# Altium schematic sheet 09_PERIPHERALS.SchDoc(09_PERIPHERALS)
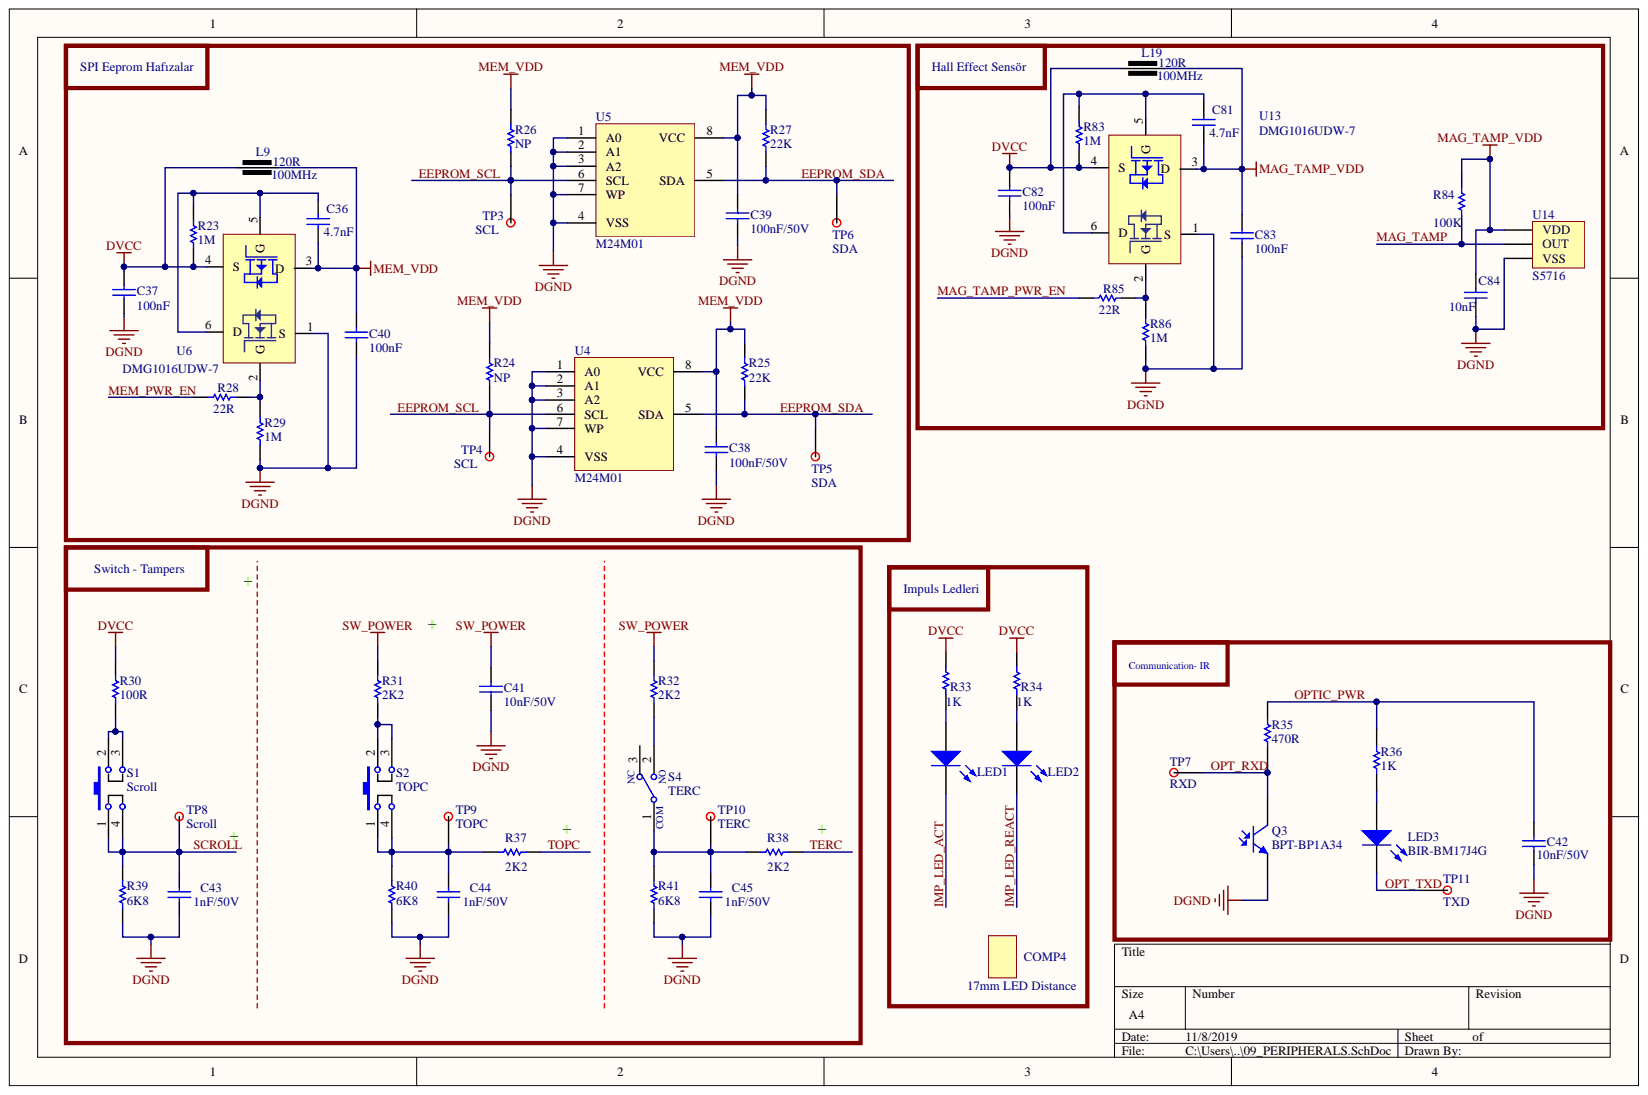
[diagram p0: 09_PERIPHERALS.SchDoc]

## Parts
C36  Capacitance: 4.7nF  [CAP_0603_V1]  (hidden comment: CAP_SMD)
C37  Capacitance: 100nF  [CAP_0603_V1]  (hidden comment: CAP_SMD)
C38  100nF  [FERRITE-BEAD_0603_V1]
C39  100nF  [FERRITE-BEAD_0603_V1]
C40  Capacitance: 100nF  [CAP_0603_V1]  (hidden comment: CAP_SMD)
C41  value 10nF/50V  [CAP_0603_V1]  (hidden comment: CAP_SMD)
C42  10nF/50V  [CAP_0603_V1]
C43  value 1nF/50V  [CAP_0603_V1]  (hidden comment: CAP_SMD)
C44  value 1nF/50V  [CAP_0603_V1]  (hidden comment: CAP_SMD)
C45  value 1nF/50V  [CAP_0603_V1]  (hidden comment: CAP_SMD)
C81  Capacitance: 4.7nF  [CAP_0603_V1]  (hidden comment: CAP_SMD)
C82  Capacitance: 100nF  [CAP_0603_V1]  (hidden comment: CAP_SMD)
C83  Capacitance: 100nF  [CAP_0603_V1]  (hidden comment: CAP_SMD)
C84  Capacitance: 10nF  [CAP_0603_V1]  (hidden comment: CAP_SMD)
COMP4  value 17mm LED Distance  [00_BOS]  (hidden comment: PCB)
L9  Test Frequency: 100MHz  Impedance: 120R  [FERRITE-BEAD_0603_V1]  (hidden comment: FERRITE BEAD)
L19  Test Frequency: 100MHz  Impedance: 120R  [FERRITE-BEAD_0603_V1]  (hidden comment: FERRITE BEAD)
LED1  LED  [LED_THT_3MM_ROUND_004]
LED2  LED  [LED_THT_3MM_ROUND_004]
LED3  017_Manufacturer-1 PN: BIR-BM17J4G  [LED_THT_5MM_IR_ROUND_002]  (hidden comment: LED_INFRARED)
Q3  017_Manufacturer-1 PN: BPT-BP1A34  [PHOTOTRANSISTOR_THT_5MM_ROUND - V2]  (hidden comment: PHOTOTRANSISTOR)
R23  004_Value: 1M  [RES_0603_V1]  (hidden comment: RES_SMD)
R24  004_Value: NP  [RES_0603_V1]  (hidden comment: 4K7/50V)
R25  22K  [RES_0603_V1]
R26  004_Value: NP  [RES_0603_V1]  (hidden comment: 4K7/50V)
R27  22K  [RES_0603_V1]
R28  004_Value: 22R  [RES_0603_V1]  (hidden comment: RES_SMD)
R29  004_Value: 1M  [RES_0603_V1]  (hidden comment: RES_SMD)
R30  value 100R  [RES_0603_V1]  (hidden comment: RES_SMD)
R31  value 2K2  [RES_0603_V1]  (hidden comment: RES_SMD)
R32  value 2K2  [RES_0603_V1]  (hidden comment: RES_SMD)
R33  Resistance: 1K  [RES_0603_V1]  (hidden comment: RES_SMD)
R34  Resistance: 1K  [RES_0603_V1]  (hidden comment: RES_SMD)
R35  470R  [RES_0603_V1]
R36  1K  [RES_0603_V1]
R37  value 2K2  [RES_0603_V1]  (hidden comment: RES_SMD)
R38  value 2K2  [RES_0603_V1]  (hidden comment: RES_SMD)
R39  value 6K8  [RES_0603_V1]  (hidden comment: RES_SMD)
R40  value 6K8  [RES_0603_V1]  (hidden comment: RES_SMD)
R41  value 6K8  [RES_0603_V1]  (hidden comment: RES_SMD)
R83  004_Value: 1M  [RES_0603_V1]  (hidden comment: RES_SMD)
R84  004_Value: 100K  [RES_0603_V1]  (hidden comment: RES_SMD)
R85  004_Value: 22R  [RES_0603_V1]  (hidden comment: RES_SMD)
R86  004_Value: 1M  [RES_0603_V1]  (hidden comment: RES_SMD)
S1  value Scroll  [SWITCH_THT_PUSH_BUTTON - V2]  (hidden comment: SWITCH-PUSH_BUTTON)
S2  value TOPC  [SWITCH_THT_PUSH_BUTTON - V2]  (hidden comment: SWITCH-PUSH_BUTTON)
S4  value TERC  [HEADER_1X2_V4]  (hidden comment: SWITCH-SPDT)
TP3  value SCL  [TEST-PAD_2.0X2.0_ROUND - No_3D_Step]  (hidden comment: TEST_POINT)
TP4  value SCL  [TEST-PAD_2.0X2.0_ROUND - No_3D_Step]  (hidden comment: TEST_POINT)
TP5  value SDA  [TEST-PAD_2.0X2.0_ROUND - No_3D_Step]  (hidden comment: TEST_POINT)
TP6  value SDA  [TEST-PAD_2.0X2.0_ROUND - No_3D_Step]  (hidden comment: TEST_POINT)
TP7  004_Value: RXD  [TEST-PAD_2.0X2.0_ROUND - No_3D_Step]  (hidden comment: TEST_POINT)
TP8  value Scroll  [TEST-PAD_2.0X2.0_ROUND - No_3D_Step]  (hidden comment: TEST_POINT)
TP9  value TOPC  [TEST-PAD_2.0X2.0_ROUND - No_3D_Step]  (hidden comment: TEST_POINT)
TP10  value TERC  [TEST-PAD_2.0X2.0_ROUND - No_3D_Step]  (hidden comment: TEST_POINT)
TP11  004_Value: TXD  [TEST-PAD_2.0X2.0_ROUND - No_3D_Step]  (hidden comment: TEST_POINT)
U4  M24M01  [SOIC-8]
U5  M24M01  [SOIC-8]
U6  DMG1016UDW-7  [SOT363(TSSOP6)_TSOP65P210X110-6N]
U13  DMG1016UDW-7  [SOT363(TSSOP6)_TSOP65P210X110-6N]
U14  S5716  [SOT23(TO-236)]

## Nets
DGND: C37.1 C38.1 C39.1 C40.1 C41.2 C42.2 C43.1 C44.1 C45.1 C82.1 C83.1 C84.1 Q3.1 R29.1 R39.2 R40.2 R41.2 R86.1 U4.1(A0) U4.2(A1) U4.3(A2) U4.4(VSS) U4.7(WP) U5.1(A0) U5.2(A1) U5.3(A2) U5.4(VSS) U5.7(WP) U6.1(S) U13.1(S) U14.3
DVCC: C37.2 C82.2 L9.1 L19.1 R23.1 R30.1 R33.2 R34.2 R83.1 U6.4(S) U13.4(S)
MAG_TAMP_VDD: C81.1 C83.2 C84.2 L19.2 R84.2 U13.3(D) U14.1
MEM_VDD: C36.1 C38.2 C39.2 C40.2 L9.2 R24.2 R25.2 R26.2 R27.2 U4.8(VCC) U5.8(VCC) U6.3(D)
SW_POWER: C41.1 R31.1 R32.1
EEPROM_SCL: R24.1 R26.1 TP3.1 TP4.1 U4.6(SCL) U5.6(SCL)
EEPROM_SDA: R25.1 R27.1 TP5.1 TP6.1 U4.5(SDA) U5.5(SDA)
IMP_LED_ACT: LED1.2
IMP_LED_REACT: LED2.2
MAG_TAMP: R84.1 U14.2
MAG_TAMP_PWR_EN: R85.1
MEM_PWR_EN: R28.1
OPT_RXD: Q3.2 R35.1 TP7.1
OPT_TXD: LED3.2 TP11.1
OPTIC_PWR: C42.1 R35.2 R36.2
SCROLL: C43.2 R39.1 S1.1 S1.4 TP8.1
TERC: R38.2
TOPC: R37.2
NetC36_2: C36.2 R23.2 U6.5(G) U6.6(D)
NetC44_2: C44.2 R37.1 R40.1 S2.1 S2.4 TP9.1
NetC45_2: C45.2 R38.1 R41.1 S4.1 TP10.1
NetC81_2: C81.2 R83.2 U13.5(G) U13.6(D)
NetLED1_1: LED1.1 R33.1
NetLED2_1: LED2.1 R34.1
NetLED3_1: LED3.1 R36.1
NetR28_2: R28.2 R29.2 U6.2(G)
NetR30_2: R30.2 S1.2 S1.3
NetR31_2: R31.2 S2.2 S2.3
NetR32_2: R32.2 S4.2
NetR85_2: R85.2 R86.2 U13.2(G)

Not connected: S4 3

## Library data of the parts
C36 C81 = CAP_SMD: 017_Manufacturer-1 PN: CL10B472KB8SFNC; 016_Manufacturer-1: Samsung; Voltage Rating: 50V; Tolerance: 10%; Parameter Name: Tape and Reel; Dielectric: X7R; 006_SMT/THT: Surface Mount; 001_Description: CAP CER 4700PF 50V X7R 0603; Width: 0.031inch; Thickness: 0.035inch; Supplier Part Number 1: CL10B472KB8SFNC-REEL; Supplier 1: Future Electronics; Stock 1: 0; Radiation Hardening: No; Package Quantity: 1; Min Operating Temperature: -55°C; Max Operating Temperature: 125°C; Library Name: ElectricMeter.SchLib; Length: 0.063inch; Height: 0.8mm; Features: Soft Termination; Depth: 0.8mm; ComponentLink1URL: http://www.samsungsem.com/kr/support/product-search/mlcc/CL10B472KB8SFNC.jsp?src-supplier=Digi-Key; ComponentLink1Description: http://www.samsungsem.com/kr/support/product-search/mlcc/CL10B472KB8SFNC.jsp?src-supplier=Digi-Key; Component Kind: Standard; Case Code (Metric): 1608; Case Code (Imperial): 0603; Case/Package: 0603
C37 C40 C82 C83 = CAP_SMD: MLCC; 100nF; 50V; X7R; ±10%; SMD; 0603; -55÷125°C; 017_Manufacturer-1 PN: CL10B104KB8NNNC; 016_Manufacturer-1: Samsung; Parameter Name: Tape and Reel; Material: Ceramic; 006_SMT/THT: Surface Mount; 001_Description: Capacitor: ceramic; MLCC; 100nF; 50V; X7R; ±10%; SMD; 0603; -55÷125°C; Width: 0.031inch; Voltage Rating (DC): 50V; Voltage Rating: 50V; Voltage: 50V; Tolerance: 10%; Thickness: 0.035inch; Supplier Part Number 1: 766-5443; Supplier 1: RSComponents; Stock 1: 0; Radiation Hardening: No; Package Quantity: 1; Min Operating Temperature: -55°C; Max Operating Temperature: 125°C; Library Name: ElectricMeter.SchLib; Length: 1.6mm; Lead Free: Lead Free; Height: 0.8mm; Dielectric: X7R; Depth: 0.8mm; Contact Plating: Tin; ComponentLink3URL: https://produktinfo.conrad.com/datenblaetter/450000-474999/456651-da-01-en-VS_KONDENSATOR_0605.pdf?src-supplier=Conrad; ComponentLink3Description: https://produktinfo.conrad.com/datenblaetter/450000-474999/456651-da-01-en-VS_KONDENSATOR_0605.pdf?src-supplier=Conrad; ComponentLink2URL: https://www.verical.com/server-webapp/html/getDatasheet?partID=89097&src-supplier=Verical; ComponentLink2Description: https://www.verical.com/server-webapp/html/getDatasheet?partID=89097&src-supplier=Verical; ComponentLink1URL: http://www.samsungsem.com/kr/support/product-search/mlcc/CL10B104KB8NNNC.jsp?src-supplier=Digi-Key; ComponentLink1Description: http://www.samsungsem.com/kr/support/product-search/mlcc/CL10B104KB8NNNC.jsp?src-supplier=Digi-Key; Component Kind: Standard; Case Code (Metric): 1608; Case Code (Imperial): 0603; Case/Package: 0603
C38 C39 = 100nF/50V: MLCC, 100nF, 50V, X7R, 0603, %10; 017_Manufacturer-1 PN: CL10B104KB8NNNC; 016_Manufacturer-1: Samsung; 008_Package: C0603; 007_Status: MP; 006_SMT/THT: SMT; 005_Process: SMD_Mounter; 001_Designation: Capacitor; Library Name: BM.10.LC02.SCHLIB; Component Kind: Standard; 004_Value: 100nF/50V
C41 = CAP_SMD: MLCC, 10nF, 50V, X7R, 0603, %10; Component Kind: Standard; Library Name: HK_SCH_BAYLAN.SchLib
C42 = CAP_SMD: MLCC, 10nF, 50V, X7R, 0603, %10; 017_Manufacturer-1 PN: GRM188R71H103KA01D; 016_Manufacturer-1: Murata; Tolerance: 10%; Parameter Name: Tape and Reel; Material: Ceramic; Insulation Resistance: 10GR; Width: 0.031inch; Voltage Rating (DC): 50V; Voltage Rating: 50V; Voltage: 50V; Thickness: 0.035inch; Termination: SMD/SMT; Supplier Part Number 1: 204-0779P; Supplier 1: RSComponents; Stock 1: 0; REACH SVHC: Unknown; Package Quantity: 1; Number of Pins: 2; Mount: Surface Mount; Min Operating Temperature: -55°C; Max Operating Temperature: 125°C; Library Name: SCH_LIB.SCHLIB; Length: 1.6mm; Lead Free: Lead Free; Height: 0.8mm; Dielectric Material: Ceramic; Dielectric: X7R; Depth: 0.8mm; ComponentLink6URL: https://produktinfo.conrad.com/datenblaetter/450000-474999/451715-da-01-en-VS_KONDENSATOR_0603.pdf?src-supplier=Conrad; ComponentLink6Description: https://produktinfo.conrad.com/datenblaetter/450000-474999/451715-da-01-en-VS_KONDENSATOR_0603.pdf?src-supplier=Conrad; ComponentLink5URL: https://www.distrelec.biz/Web/Downloads/_t/ds/murata_MLCC_eng_tds.pdf?mime=application%2Fpdf&src-supplier=Distrelec; ComponentLink5Description: https://www.distrelec.biz/Web/Downloads/_t/ds/murata_MLCC_eng_tds.pdf?mime=application%2Fpdf&src-supplier=Distrelec; ComponentLink4URL: http://www.farnell.com/datasheets/2047844.pdf?src-supplier=Element14; ComponentLink4Description: http://www.farnell.com/datasheets/2047844.pdf?src-supplier=Element14; ComponentLink3URL: http://www.farnell.com/datasheets/4360.pdf?src-supplier=Element14; ComponentLink3Description: http://www.farnell.com/datasheets/4360.pdf?src-supplier=Element14; ComponentLink2URL: http://uk.rs-online.com/webdocs/0030/0900766b8003061f.pdf?src-supplier=RSComponents; ComponentLink2Description: http://uk.rs-online.com/webdocs/0030/0900766b8003061f.pdf?src-supplier=RSComponents; ComponentLink1URL: https://www.murata.com/~/media/webrenewal/support/library/catalog/products/capacitor/mlcc/c02e.ashx?la=en-us&src-supplier=Digi-Key; ComponentLink1Description: https://www.murata.com/~/media/webrenewal/support/library/catalog/products/capacitor/mlcc/c02e.ashx?la=en-us&src-supplier=Digi-Key; Component Kind: Standard; Case Code (Metric): 1608; Case Code (Imperial): 0603; Case/Package: 0603; Capacitance: 10nF
C43-C45 = CAP_SMD: MLCC, 1nF, 50V, X7R, 0603, %10; 017_Manufacturer-1 PN 1: CL10B102KB8NNNC; 016_Manufacturer-1 1: Samsung; Tolerance: 10%; Material: Ceramic; Width: 0.031inch; Voltage Rating (DC): 50V; Voltage Rating: 50V; Voltage: 50V; Thickness: 0.035inch; Supplier Part Number 1: 1276-1018-1-ND; Supplier 1: Digi-Key; Stock 1: 1355303; RoHS: Yes; Radiation Hardening: No; Pricing 1: 1=0.10000, 10=0.04200, 100=0.01890, 500=0.01348, 1000=0.01059 (USD); Packaging: Tape and Reel; Package Quantity: 1; Mount: Surface Mount; Min Operating Temperature: -55°C; Max Operating Temperature: 125°C; Length: 1.6mm; Lead Free: Lead Free; Height: 0.8mm; Dielectric: X7R; Depth: 0.8mm; Contact Plating: Tin; ComponentLink5URL: https://datasheet.ciiva.com/16199/cl05b104kq5nnnc-16199064.pdf?src-supplier=Future+Electronics; ComponentLink5Description: https://datasheet.ciiva.com/16199/cl05b104kq5nnnc-16199064.pdf?src-supplier=Future+Electronics; ComponentLink4URL: https://datasheet.ciiva.com/26310/mlcc-catalogdownload2013-eng-26310326.pdf?src-supplier=Distrelec; ComponentLink4Description: https://datasheet.ciiva.com/26310/mlcc-catalogdownload2013-eng-26310326.pdf?src-supplier=Distrelec; ComponentLink3URL: https://datasheet.ciiva.com/29198/458300-da-01-en-vs-kondensator-0603-29198498.pdf?src-supplier=Conrad; ComponentLink3Description: https://datasheet.ciiva.com/29198/458300-da-01-en-vs-kondensator-0603-29198498.pdf?src-supplier=Conrad; ComponentLink2URL: https://datasheet.ciiva.com/15216/getdatasheetpartid-359690-15216231.pdf?src-supplier=Verical; ComponentLink2Description: https://datasheet.ciiva.com/15216/getdatasheetpartid-359690-15216231.pdf?src-supplier=Verical; ComponentLink1URL: https://datasheet.ciiva.com/25805/cl10b102kb8nnnc-25805137.pdf?src-supplier=Digi-Key; ComponentLink1Description: https://datasheet.ciiva.com/25805/cl10b102kb8nnnc-25805137.pdf?src-supplier=Digi-Key; Component Kind: Standard; Case Code (Metric): 1608; Case Code (Imperial): 0603; Case/Package: 0603; Capacitance: 1nF; Library Name: HK_SCH_BAYLAN.SchLib
C84 = CAP_SMD: 017_Manufacturer-1 PN: CL10B103KB8NNNC; 016_Manufacturer-1: Samsung; Voltage Rating (DC): 50V; Tolerance: 10%; Parameter Name: Tape and Reel; Material: Ceramic; Dielectric: X7R; 006_SMT/THT: Surface Mount; 001_Description: Cap Ceramic 0.01uF 50V X7R 10% SMD 0603 125C T/R; Width: 0.031inch; Voltage Rating: 50V; Voltage: 50V; Thickness: 0.035inch; Supplier Part Number 1: 7665373; Supplier 1: RSComponents; Stock 1: 0; Radiation Hardening: No; Package Quantity: 1; Min Operating Temperature: -55°C; Max Operating Temperature: 125°C; Library Name: ElectricMeter.SchLib; Length: 1.6mm; Lead Free: Lead Free; Height: 0.8mm; Depth: 0.8mm; Contact Plating: Tin; ComponentLink3URL: https://produktinfo.conrad.com/datenblaetter/450000-474999/457455-da-01-en-VS_KONDENSATOR_0603.pdf?src-supplier=Conrad; ComponentLink3Description: https://produktinfo.conrad.com/datenblaetter/450000-474999/457455-da-01-en-VS_KONDENSATOR_0603.pdf?src-supplier=Conrad; ComponentLink2URL: https://www.verical.com/server-webapp/html/getDatasheet?partID=357415&src-supplier=Verical; ComponentLink2Description: https://www.verical.com/server-webapp/html/getDatasheet?partID=357415&src-supplier=Verical; ComponentLink1URL: http://www.rapidonline.com/pdf/71-0315.pdf?src-supplier=Rapid+Electronics; ComponentLink1Description: http://www.rapidonline.com/pdf/71-0315.pdf?src-supplier=Rapid+Electronics; Component Kind: Standard; Case Code (Metric): 1608; Case Code (Imperial): 0603; Case/Package: 0603
COMP4 = 00_BOS: LED Distance, 17mm for LED2 and LED3; Component Kind: Standard; Library Name: HK_SCH_BAYLAN.SchLib
L9 L19 = FERRITE BEAD: Inductor, Ferrite Bead, 120R@100Mhz, Power Line, 2A; 048_Manufacturer-2 PN: CIC10P121NC; 047_Manufacturer-2: SAMSUNG; 017_Manufacturer-1 PN: BLM18PG121SN1D; 016_Manufacturer-1: Murata; Tolerance: 25%; Shielding: Unshielded; Parameter Name: Tape and Reel; Number of Lines: 1; Material: Steel,Ferrite; DC Resistance (DCR): 50mR; 001_Description: MURATA - BLM18PG121SN1D - FERRITE BEAD, 120 OHM, 2A, 0603; Width: 0.8mm; Termination: SMD/SMT; Supplier Part Number 1: 724-1380; Supplier 1: RSComponents; Stock 1: 0; REACH SVHC: No SVHC; Radiation Hardening: No; Package Quantity: 1; Number of Pins: 2; Number of Circuits: 1; Min Operating Temperature: -55°C; Max Operating Temperature: 125°C; Max DC Current: 2A; Max Current Rating: 2A; Library Name: ElectricMeter.SchLib; Length: 1.6mm; Lead Free: Lead Free; Height: 0.8mm; Frequency: 100MHz; Depth: 0.8mm; DC Current: 2A; Current Rating: 2A; Core Material: Ferrite; ComponentLink11URL: http://www.produktinfo.conrad.com/datenblaetter/1100000-1199999/001172297-da-01-en-DROSSEL_0603_120R_1_6X0_8MM_4000ST.pdf?src-supplier=Conrad; ComponentLink11Description: http://www.produktinfo.conrad.com/datenblaetter/1100000-1199999/001172297-da-01-en-DROSSEL_0603_120R_1_6X0_8MM_4000ST.pdf?src-supplier=Conrad; ComponentLink10URL: http://www.produktinfo.conrad.com/datenblaetter/425000-449999/442365-da-01-en-CHIP_DROSSEL_0603_120R_2000MA_1_6X0_8MM.pdf?src-supplier=Conrad; ComponentLink10Description: http://www.produktinfo.conrad.com/datenblaetter/425000-449999/442365-da-01-en-CHIP_DROSSEL_0603_120R_2000MA_1_6X0_8MM.pdf?src-supplier=Conrad; ComponentLink9URL: http://www.farnell.com/datasheets/1740433.pdf?src-supplier=Avnet+Europe; ComponentLink9Description: http://www.farnell.com/datasheets/1740433.pdf?src-supplier=Avnet+Europe; ComponentLink8URL: http://www.farnell.com/datasheets/1740433.pdf?src-supplier=Jameco; ComponentLink8Description: http://www.farnell.com/datasheets/1740433.pdf?src-supplier=Jameco; ComponentLink7URL: http://uk.rs-online.com/webdocs/13d6/0900766b813d688c.pdf?src-supplier=RSComponents; ComponentLink7Description: http://uk.rs-online.com/webdocs/13d6/0900766b813d688c.pdf?src-supplier=RSComponents; ComponentLink6URL: http://www.farnell.com/datasheets/1740433.pdf?src-supplier=Distrelec; ComponentLink6Description: http://www.farnell.com/datasheets/1740433.pdf?src-supplier=Distrelec; ComponentLink5URL: http://www.farnell.com/datasheets/1740433.pdf?src-supplier=Arrow; ComponentLink5Description: http://www.farnell.com/datasheets/1740433.pdf?src-supplier=Arrow; ComponentLink4URL: https://www.murata.com/en-us/products/productdata/8796738650142/ENFA0003.pdf?src-supplier=Digi-Key; ComponentLink4Description: https://www.murata.com/en-us/products/productdata/8796738650142/ENFA0003.pdf?src-supplier=Digi-Key; ComponentLink3URL: http://www.farnell.com/datasheets/1740433.pdf?src-supplier=Element14; ComponentLink3Description: http://www.farnell.com/datasheets/1740433.pdf?src-supplier=Element14; ComponentLink2URL: http://www.farnell.com/datasheets/1740433.pdf?src-supplier=Farnell; ComponentLink2Description: http://www.farnell.com/datasheets/1740433.pdf?src-supplier=Farnell; ComponentLink1URL: http://www.farnell.com/datasheets/1740433.pdf?src-supplier=Avnet; ComponentLink1Description: http://www.farnell.com/datasheets/1740433.pdf?src-supplier=Avnet; Component Kind: Standard; Case Code (Metric): 1608; Case Code (Imperial): 0603; Case/Package: 0603
LED1 LED2 = LED_1: LED, 3mm, Water Clear, RED,High Brightness; 019_Manufacturer-1 Digi-Key PN: 204-10SURC/S400-A8-ND; 017_Manufacturer-1 PN: 204-10SURC/S400-A8; 016_Manufacturer-1: Everlight; 007_Status: MP; 006_SMT/THT: THT; 005_Process: Manuel_Solder; Library Name: SCH_LIB.SCHLIB; Component Kind: Standard; 008_Package: -; 004_Value: 3mm; 003_Remark: -; 001_Designation: LED
LED3 = LED_INFRARED: LED, IR, 940nm, ±25°, 3mm; 016_Manufacturer-1: BRIGHT LED; 008_Package: 5mm Round; 007_Status: MP; 006_SMT/THT: THT; 005_Process: Manuel_Solder; Library Name: SCH_LIB.SCHLIB; Component Kind: Standard; 019_Manufacturer-1 Digi-Key PN: -; 004_Value: BIR-BM17J4G; 001_Designation: LED
Q3 = PHOTOTRANSISTOR: Phototransistor, 5mm, 925nm, ±30°; 016_Manufacturer-1: BRIGHT LED; 008_Package: 5mm Round; 007_Status: MP; 006_SMT/THT: THT; 005_Process: Manuel Solder; Library Name: SCH_LIB.SCHLIB; Component Kind: Standard; 019_Manufacturer-1 Digi-Key PN: -; 004_Value: BPT-BP1A34; 003_Remark: -; 001_Designation: Phototransistor
R23 R29 R83 = RES_SMD: 017_Manufacturer-1 PN: 0603SAJ0105TDE; 016_Manufacturer-1: Royalohm; Library Name: ElectricMeter.SchLib; Component Kind: Standard
R24 R26 = 4K7/50V: Chip Resistor, 4K7, 1/10W, 1%, 0603; 017_Manufacturer-1 PN: RC0603FR-074K7L; 016_Manufacturer-1: Yageo; 008_Package: R0603; 007_Status: MP; 006_SMT/THT: SMT; 005_Process: SMD_Mounter; Library Name: BM.10.LC02.SCHLIB; Component Kind: Standard; 001_Designation: Resistor
R25 R27 = 22K/50V: Chip Resistor, 22K, 1/10W, 1%, 0603; 017_Manufacturer-1 PN: RC0603FR-0722KL; 016_Manufacturer-1: Yageo; 008_Package: R0603; 007_Status: MP; 006_SMT/THT: SMT; 005_Process: SMD_Mounter; Library Name: BM.10.LC02.SCHLIB; Component Kind: Standard; 004_Value: 22K; 001_Designation: Resistor
R28 R85 = RES_SMD: 017_Manufacturer-1 PN: 0603SAJ0220T5E; 016_Manufacturer-1: Royal Electronic Factory; 001_Description: Resistor: thick film; SMD; 0603; 22O; 0.1W; ±5%; -55÷125°C; Supplier Part Number 1: 0603SAJ0220T5E; Supplier 1: TME; Stock 1: 0; Pricing 1: 5000=0.00135, 15000=0.00114, 50000=0.00104 (USD); Library Name: ElectricMeter.SchLib; Component Kind: Standard
R30 = RES_SMD: Chip Resistor, 100R, 1/10W, 1%, 0603; 017_Manufacturer-1 PN: 0603WAJ0101T5E; 016_Manufacturer-1: Royalohm; Component Kind: Standard; Library Name: HK_SCH_BAYLAN.SchLib
R31 R32 = RES_SMD: Chip Resistor, 2K2, 1/10W, 1%, 0603; 017_Manufacturer-1 PN 1: RC0603JR-072K2L; 016_Manufacturer-1 1: Yageo; Voltage Rating (DC): 50V; Tolerance: 5%; Temperature Coefficient: 100ppm/°C; Resistance: 2.2kR; Power Rating: 100mW; Width: 0.8mm; Voltage Rating: 50V; Termination: SMD/SMT; Supplier Part Number 1: 311-2.2KGRCT-ND; Supplier 1: Digi-Key; Stock 1: 7876469; RoHS: Yes; REACH SVHC: Unknown; Pricing 1: 1=0.10000, 10=0.01900, 100=0.00770, 1000=0.00347, 2500=0.00301 (USD); Packaging: Tape and Reel; Number of Pins: 2; Min Operating Temperature: -55°C; Military Standard: Not; Max Operating Temperature: 155°C; Length: 1.6mm; Lead Free: Lead Free; Depth: 0.8mm; Composition: Thick Film; ComponentLink7URL: http://www.yageo.com/documents/recent/PYu-RC0603_51_RoHS_L_7.pdf?src-supplier=Future+Electronics; ComponentLink7Description: http://www.yageo.com/documents/recent/PYu-RC0603_51_RoHS_L_7.pdf?src-supplier=Future+Electronics; ComponentLink6URL: http://www.farnell.com/datasheets/2167052.pdf?src-supplier=Newark; ComponentLink6Description: http://www.farnell.com/datasheets/2167052.pdf?src-supplier=Newark; ComponentLink5URL: http://www.yageo.com/documents/recent/PYu-RC_Group_51_RoHS_L_10.pdf?src-supplier=Digi-Key; ComponentLink5Description: http://www.yageo.com/documents/recent/PYu-RC_Group_51_RoHS_L_10.pdf?src-supplier=Digi-Key; ComponentLink4URL: http://www.farnell.com/datasheets/1868787.pdf?src-supplier=Element14; ComponentLink4Description: http://www.farnell.com/datasheets/1868787.pdf?src-supplier=Element14; ComponentLink3URL: http://components.arrow.com/part/dataview/0323_00047615?src-supplier=Arrow+Europe; ComponentLink3Description: http://components.arrow.com/part/dataview/0323_00047615?src-supplier=Arrow+Europe; ComponentLink2URL: http://www.farnell.com/datasheets/2167052.pdf?src-supplier=Farnell; ComponentLink2Description: http://www.farnell.com/datasheets/2167052.pdf?src-supplier=Farnell; ComponentLink1URL: http://www.mouser.com/ds/2/447/RC0603-272842.pdf?src-supplier=Mouser; ComponentLink1Description: http://www.mouser.com/ds/2/447/RC0603-272842.pdf?src-supplier=Mouser; Component Kind: Standard; Case Code (Metric): 1608; Case Code (Imperial): 0603; Case/Package: 0603; Library Name: HK_SCH_BAYLAN.SchLib
R33 R34 = RES_SMD: 017_Manufacturer-1 PN: 0603SAF1001T5E; 016_Manufacturer-1: Royal Electronic Factory; Tolerance: 1%; 001_Description: Resistor: thick film; SMD; 0603; 1kΩ; 0.1W; ±1%; -55÷125°C; Supplier Part Number 1: 0603SAF1001T5E; Supplier 1: Quest; Stock 1: 0; Library Name: ElectricMeter.SchLib; Component Kind: Standard; Case/Package: 0603
R35 = RC0603FR-07470RL: RES SMD 470 OHM 1% 1/10W 0603; 016_Manufacturer-1: Yageo; Working Voltage: 75V; Voltage Rating (DC): 10V; Voltage Rating: 50V; Temperature Coefficient: 100ppm/°C; Power Rating: 100mW; Parameter Name: Tape and Reel; Dielectric: X5R; Capacitance: 10uF; Width: 0.031inch; Voltage: 10V; Tolerance: 1%; Thickness: 0.037inch; Termination: SMD/SMT; Supplier Part Number 2: GRM188R61A106KE69D; Supplier Part Number 1: RC0603FR-07470RL; Supplier 2: Arrow; Supplier 1: Arrow; Stock 2: 2410; Stock 1: 8212641; Resistance: 470R; REACH SVHC: No SVHC; Pricing 2: 1=0.0335 (USD); Pricing 1: 5000=0.0019, 10000=0.0011, 1=0.0017, 25=0.0016, 1000=0.0015 (USD); Package Quantity: 1; Number of Terminations: 2; Mount: Surface Mount; Min Operating Temperature: -55°C; Military Standard: Not; Max Power Dissipation: 100mW; Max Operating Temperature: 155°C; Library Name: ElectricMeter.SchLib; Length: 1.6mm; Lead Free: Lead Free; Height: 0.022inch; Features: Moisture Resistant; Depth: 0.8mm; Composition: Thick Film; ComponentLink4URL: http://www.yageo.com/documents/recent/PYu-RC_Group_51_RoHS_L_10.pdf?src-supplier=Digi-Key; ComponentLink4Description: http://www.yageo.com/documents/recent/PYu-RC_Group_51_RoHS_L_10.pdf?src-supplier=Digi-Key; ComponentLink3URL: http://www.farnell.com/datasheets/2167052.pdf?src-supplier=Element14; ComponentLink3Description: http://www.farnell.com/datasheets/2167052.pdf?src-supplier=Element14; ComponentLink2URL: http://www.farnell.com/datasheets/1868787.pdf?src-supplier=Element14; ComponentLink2Description: http://www.farnell.com/datasheets/1868787.pdf?src-supplier=Element14; ComponentLink1URL: http://documents.rs-components.com/techlib://itc_doclist_GB.htm?stockno=5047341&src-supplier=RSComponents; ComponentLink1Description: http://documents.rs-components.com/techlib://itc_doclist_GB.htm?stockno=5047341&src-supplier=RSComponents; Component Kind: Standard; Case Code (Metric): 1608; Case Code (Imperial): 0603; Case/Package: 0603; 017_Manufacturer-1 PN: RC0603FR-07470RL
R36 = RC0603FR-071KL: RES SMD 1K OHM 1% 1/10W 0603; Manufacturer: Yageo; 017_Manufacturer-1 PN: 0603SAJ0102T5E; 016_Manufacturer-1: Royalohm; Voltage Rating: 50V; Temperature Coefficient: 100ppm/°C; Resistance: 1kR; Power Rating: 100mW; Parameter Name: Tape and Reel; Tolerance: 1%; Termination: SMD/SMT; Supplier Part Number 1: RC0603FR-07 1KL; Supplier 1: Verical; Stock 1: 0; REACH SVHC: No SVHC; Radiation Hardening: No; Min Operating Temperature: -55°C; Military Standard: Not; Max Operating Temperature: 155°C; Manufacturer Part Number: RC0603FR-071KL; Library Name: ElectricMeter.SchLib; Length: 1.6mm; Lead Free: Lead Free; Height: 0.45mm; Depth: 0.8mm; Contact Plating: Matte,Tin; Composition: Thick Film; ComponentLink7URL: http://www.farnell.com/datasheets/443971.pdf?src-supplier=Element14; ComponentLink7Description: http://www.farnell.com/datasheets/443971.pdf?src-supplier=Element14; ComponentLink6URL: https://www.verical.com/server-webapp/html/getDatasheet?partID=404327&src-supplier=Verical; ComponentLink6Description: https://www.verical.com/server-webapp/html/getDatasheet?partID=404327&src-supplier=Verical; ComponentLink5URL: http://www.yageo.com/documents/recent/PYu-RC_Group_51_RoHS_L_2.pdf?src-supplier=Avnet; ComponentLink5Description: http://www.yageo.com/documents/recent/PYu-RC_Group_51_RoHS_L_2.pdf?src-supplier=Avnet; ComponentLink4URL: http://www.farnell.com/datasheets/1868787.pdf?src-supplier=Element14; ComponentLink4Description: http://www.farnell.com/datasheets/1868787.pdf?src-supplier=Element14; ComponentLink3URL: http://www.farnell.com/datasheets/2167052.pdf?src-supplier=Element14; ComponentLink3Description: http://www.farnell.com/datasheets/2167052.pdf?src-supplier=Element14; ComponentLink2URL: http://www.yageo.com/documents/recent/PYu-RC0603_51_RoHS_L_4.pdf?src-supplier=Avnet+Europe; ComponentLink2Description: http://www.yageo.com/documents/recent/PYu-RC0603_51_RoHS_L_4.pdf?src-supplier=Avnet+Europe; ComponentLink1URL: http://documents.rs-components.com/techlib://itc_doclist_GB.htm?stockno=5048928&src-supplier=RSComponents; ComponentLink1Description: http://documents.rs-components.com/techlib://itc_doclist_GB.htm?stockno=5048928&src-supplier=RSComponents; Component Kind: Standard; Case Code (Metric): 1608; Case Code (Imperial): 0603; Case/Package: 0603
R37 R38 = RES_SMD: Chip Resistor, 2K2, 1/10W, 1%, 0603; Component Kind: Standard; Library Name: HK_SCH_BAYLAN.SchLib
R39-R41 = RES_SMD: Chip Resistor, 6K8, 1/10W, 1%, 0603; 017_Manufacturer-1 PN 1: RC0603FR-076K8L; 016_Manufacturer-1 1: Yageo; Voltage Rating (DC): 50V; Temperature Coefficient: 100ppm/°C; Resistance: 6.8kR; Power Rating: 100mW; Width: 0.031inch; Voltage Rating: 50V; Tolerance: 1%; Termination: SMD/SMT; Supplier Part Number 1: 311-6.80KHRCT-ND; Supplier 1: Digi-Key; Stock 1: 3661442; RoHS: Yes; REACH SVHC: No SVHC; Pricing 1: 1=0.10000, 10=0.02300, 100=0.00940, 1000=0.00421, 2500=0.00366 (USD); Packaging: Tape and Reel; Package Quantity: 1; Number of Terminations: 2; Number of Pins: 2; Min Operating Temperature: -55°C; Military Standard: Not; Max Power Dissipation: 100mW; Max Operating Temperature: 155°C; Length: 0.063inch; Lead Free: Lead Free; Height: 0.022inch; Features: Moisture Resistant; Depth: 0.8mm; Composition: Thick Film; ComponentLink8URL: https://datasheet.ciiva.com/7746/443971-7746394.pdf?src-supplier=Element14; ComponentLink8Description: https://datasheet.ciiva.com/7746/443971-7746394.pdf?src-supplier=Element14; ComponentLink7URL: https://datasheet.ciiva.com/46412/443971-46412856.pdf?src-supplier=Element14; ComponentLink7Description: https://datasheet.ciiva.com/46412/443971-46412856.pdf?src-supplier=Element14; ComponentLink6URL: https://datasheet.ciiva.com/46371/1868787-46371519.pdf?src-supplier=Element14; ComponentLink6Description: https://datasheet.ciiva.com/46371/1868787-46371519.pdf?src-supplier=Element14; ComponentLink5URL: https://datasheet.ciiva.com/7983/443971-7983662.pdf?src-supplier=Element14; ComponentLink5Description: https://datasheet.ciiva.com/7983/443971-7983662.pdf?src-supplier=Element14; ComponentLink4URL: https://datasheet.ciiva.com/2454/pyu-r-int-thick-7-2454158.pdf?src-supplier=Digi-Key; ComponentLink4Description: https://datasheet.ciiva.com/2454/pyu-r-int-thick-7-2454158.pdf?src-supplier=Digi-Key; ComponentLink3URL: https://datasheet.ciiva.com/2454/pyu-r-int-thick-7-2454157.pdf?src-supplier=Digi-Key; ComponentLink3Description: https://datasheet.ciiva.com/2454/pyu-r-int-thick-7-2454157.pdf?src-supplier=Digi-Key; ComponentLink2URL: https://datasheet.ciiva.com/2454/pyu-r-int-thick-7-2454156.pdf?src-supplier=Digi-Key; ComponentLink2Description: https://datasheet.ciiva.com/2454/pyu-r-int-thick-7-2454156.pdf?src-supplier=Digi-Key; ComponentLink1URL: https://datasheet.ciiva.com/7746/443971-7746395.pdf?src-supplier=Element14; ComponentLink1Description: https://datasheet.ciiva.com/7746/443971-7746395.pdf?src-supplier=Element14; Component Kind: Standard; Case Code (Metric): 1608; Case Code (Imperial): 0603; Case/Package: 0603; Library Name: HK_SCH_BAYLAN.SchLib
R84 = RES_SMD: 017_Manufacturer-1 PN: 0603SAF1003T5E; 016_Manufacturer-1: Royal Electronic Factory; 001_Description: Resistor: thick film; SMD; 0603; 100kO; 0.1W; ±1%; -55÷125°C; Supplier Part Number 1: SMD0603-100K-1%; Supplier 1: TME; Stock 1: 0; Pricing 1: 100=0.0122, 1000=0.0033, 5000=0.0017, 15000=0.00143, 50000=0.00122 (USD); Library Name: ElectricMeter.SchLib; Component Kind: Standard
R86 = RES_SMD: 0603 KILIF THICK FILM 5% TOLERANS 1/10W; 017_Manufacturer-1 PN: 0603SAJ0105TDE; 016_Manufacturer-1: Royalohm; Library Name: ElectricMeter.SchLib; Component Kind: Standard
S1 S2 = SWITCH-PUSH_BUTTON: Switch, Tactile, 6x6/6.2x6.2, h:7mm, OF:70gf, SS Dome; Component Kind: Standard; Library Name: HK_SCH_BAYLAN.SchLib
S4 = SWITCH-SPDT: Single-Pole, Double-Throw Switch; Component Kind: Standard; Library Name: HK_SCH_BAYLAN.SchLib
TP3-TP6 TP8-TP10 = TEST_POINT: Test Point, 2mm; Component Kind: Standard; Library Name: HK_SCH_BAYLAN.SchLib
TP7 TP11 = TEST_POINT: Test Point, 2mm; 007_Status: TP; 006_SMT/THT: SMT; Library Name: SCH_LIB.SCHLIB; Component Kind: Standard; 008_Package: -; 005_Process: Test Point; 003_Remark: -; 001_Designation: Test Point
U4 U5 = MEMORY_EEPROM_I2C_M24XXX: IC, 1Mb, Serial I²C Bus EEPROM; 048_Manufacturer-2 PN: BL24CM1A; 047_Manufacturer-2: Belling; 019_Manufacturer-1 Digi-Key PN: 497-6351-2-ND; 017_Manufacturer-1 PN: M24M01-RMN6TP; 016_Manufacturer-1: ST Microelectronics; 007_Status: MP; 006_SMT/THT: SMT; 005_Process: SMD_Mounter; Library Name: BM.10.LC02.SCHLIB; Component Kind: Standard; 008_Package: SOIC-8; 004_Value: M24M01; 003_Remark: -; 001_Designation: EEPROM
U6 U13 = DMG1016UDW-7: MOSFET 20V Vdss 6V VGSS Complementary Pair; 016_Manufacturer-1: Diodes Zetex; Turn-On Delay Time: 5.1ns; Turn-Off Delay Time: 26.7ns; Threshold Voltage: 1V; Rise Time: 7.4ns; Rds On Max: 450mR; Power Dissipation: 330mW; Parameter Name: Tape and Reel; On-State Resistance: 750mR; Number of Elements: 2; Input Capacitance: 60.67pF; FET Type: N and P-Channel; Fall Time: 12.3ns; Drain to Source Resistance: 1.05R; Continuous Drain Current (ID): 845mA; Width: 1.35mm; Weight: 0.000212oz; Supplier Part Number 1: DMG1016UDW-7DIDKR-ND; Supplier 1: Digi-Key; Stock 1: 0; REACH SVHC: No SVHC; Radiation Hardening: No; Pricing 1: 1=0.57, 10=0.408, 100=0.2402, 500=0.13596, 1000=0.10424 (USD); Package Quantity: 3000; Number of Pins: 6; Number of Channels: 2; Mount: Surface Mount; Min Operating Temperature: -55°C; Max Power Dissipation: 330mW; Max Operating Temperature: 150°C; Library Name: ElectricMeter.SchLib; Length: 2.2mm; Lead Free: Lead Free; Height: 1mm; Gate to Source Voltage (Vgs): 6V; Drain to Source Voltage (Vdss): 20V; Drain to Source Breakdown Voltage: 20V; Contact Plating: Matte,Tin; ComponentLink4URL: http://www.mouser.com/ds/2/115/ds31860-67411.pdf; ComponentLink4Description: http://www.mouser.com/ds/2/115/ds31860-67411.pdf; ComponentLink3URL: http://www.diodes.com/_files/datasheets/ds31860.pdf; ComponentLink3Description: http://www.diodes.com/_files/datasheets/ds31860.pdf; ComponentLink2URL: https://www.diodes.com/assets/Datasheets/ds31860.pdf; ComponentLink2Description: https://www.diodes.com/assets/Datasheets/ds31860.pdf; ComponentLink1URL: http://www.diodes.com//datasheets/ds31860.pdf; ComponentLink1Description: http://www.diodes.com//datasheets/ds31860.pdf; Component Kind: Standard; Case/Package: SOT-363; 017_Manufacturer-1 PN: DMG1016UDW-7
U14 = S5716: High-accuracy Hall IC; 017_Manufacturer-1 PN: S-5716ANDL0-M3T1U; 016_Manufacturer-1: Seiko; 001_Description: IC HALL EFFECT SENSOR SOT23-3; Supplier Part Number 1: S-5716ANDL0-M3T1U; Supplier 1: Perfect Parts; Stock 1: 0; Library Name: ElectricMeter.SchLib; Lead Free: Lead Free; Component Kind: Standard; Case/Package: SOT-23-3
